# Altium schematic sheet OpAmpAndADC.SchDoc(OpAmpAndADC)
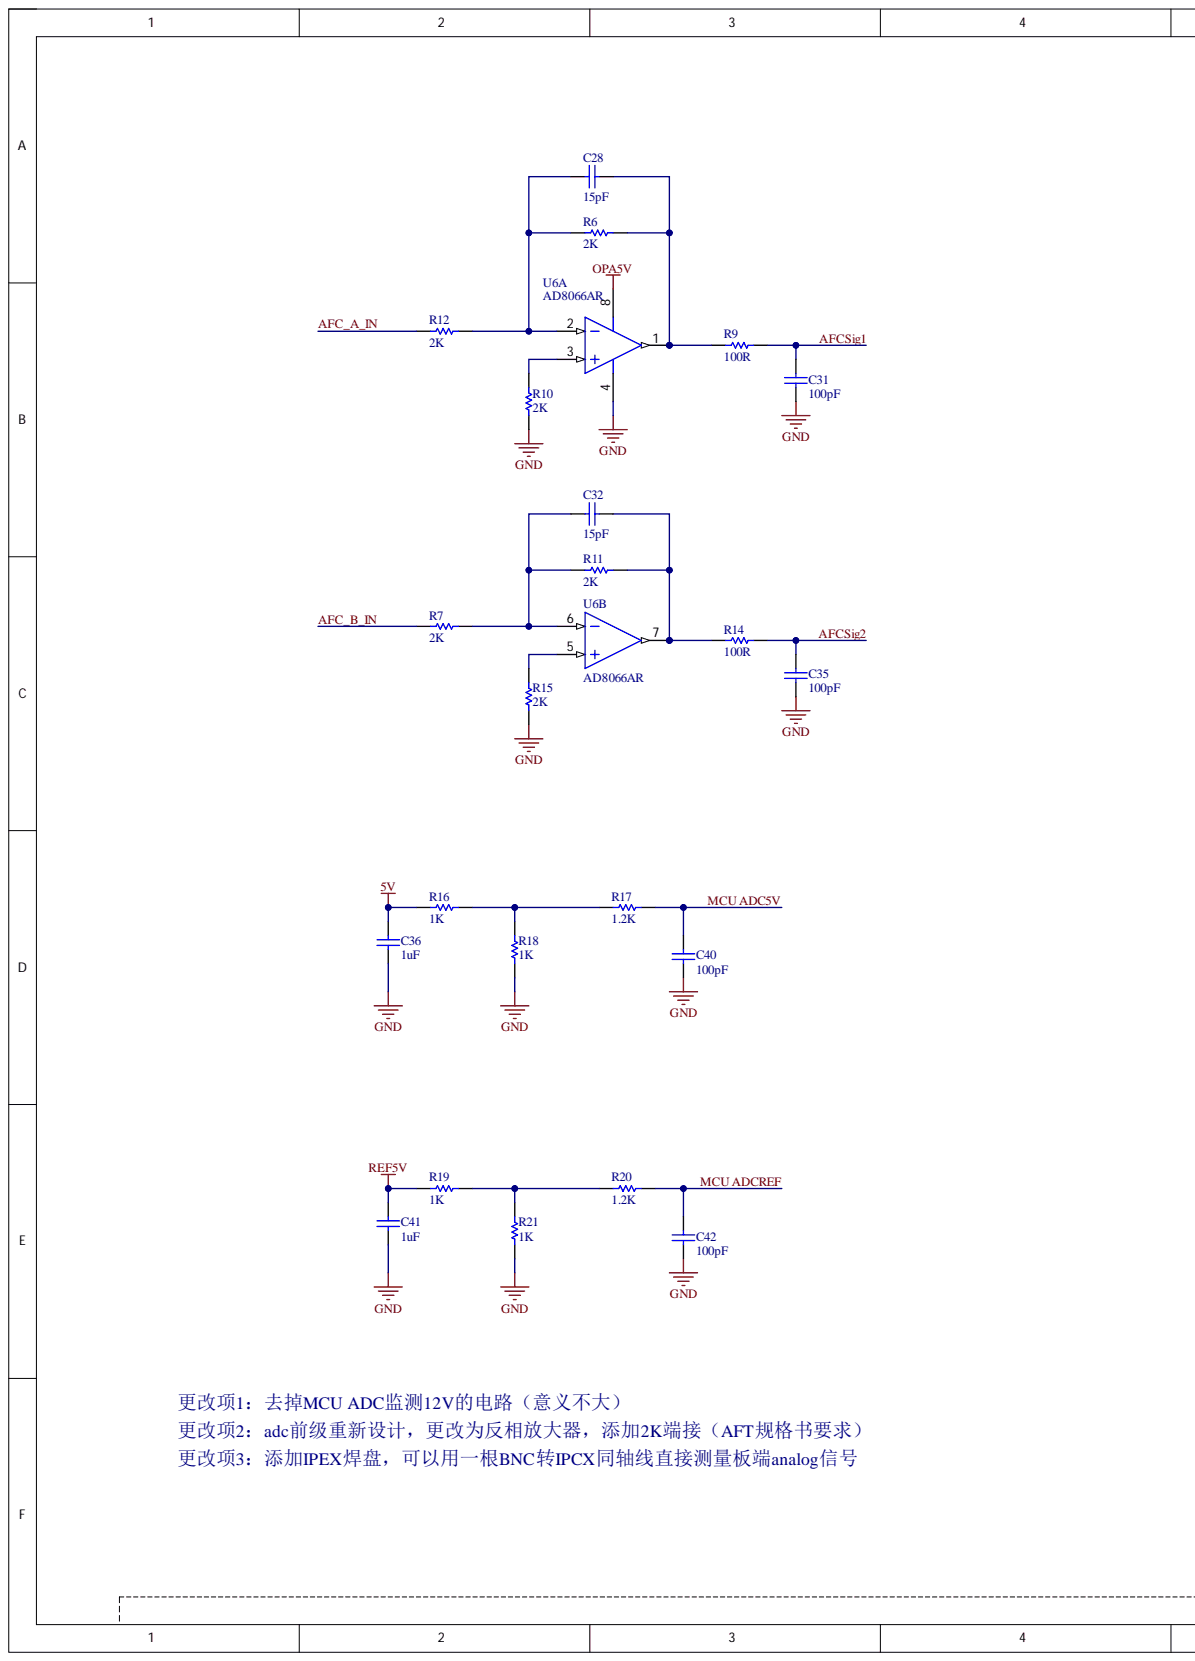
[diagram p0: OpAmpAndADC.SchDoc, left]
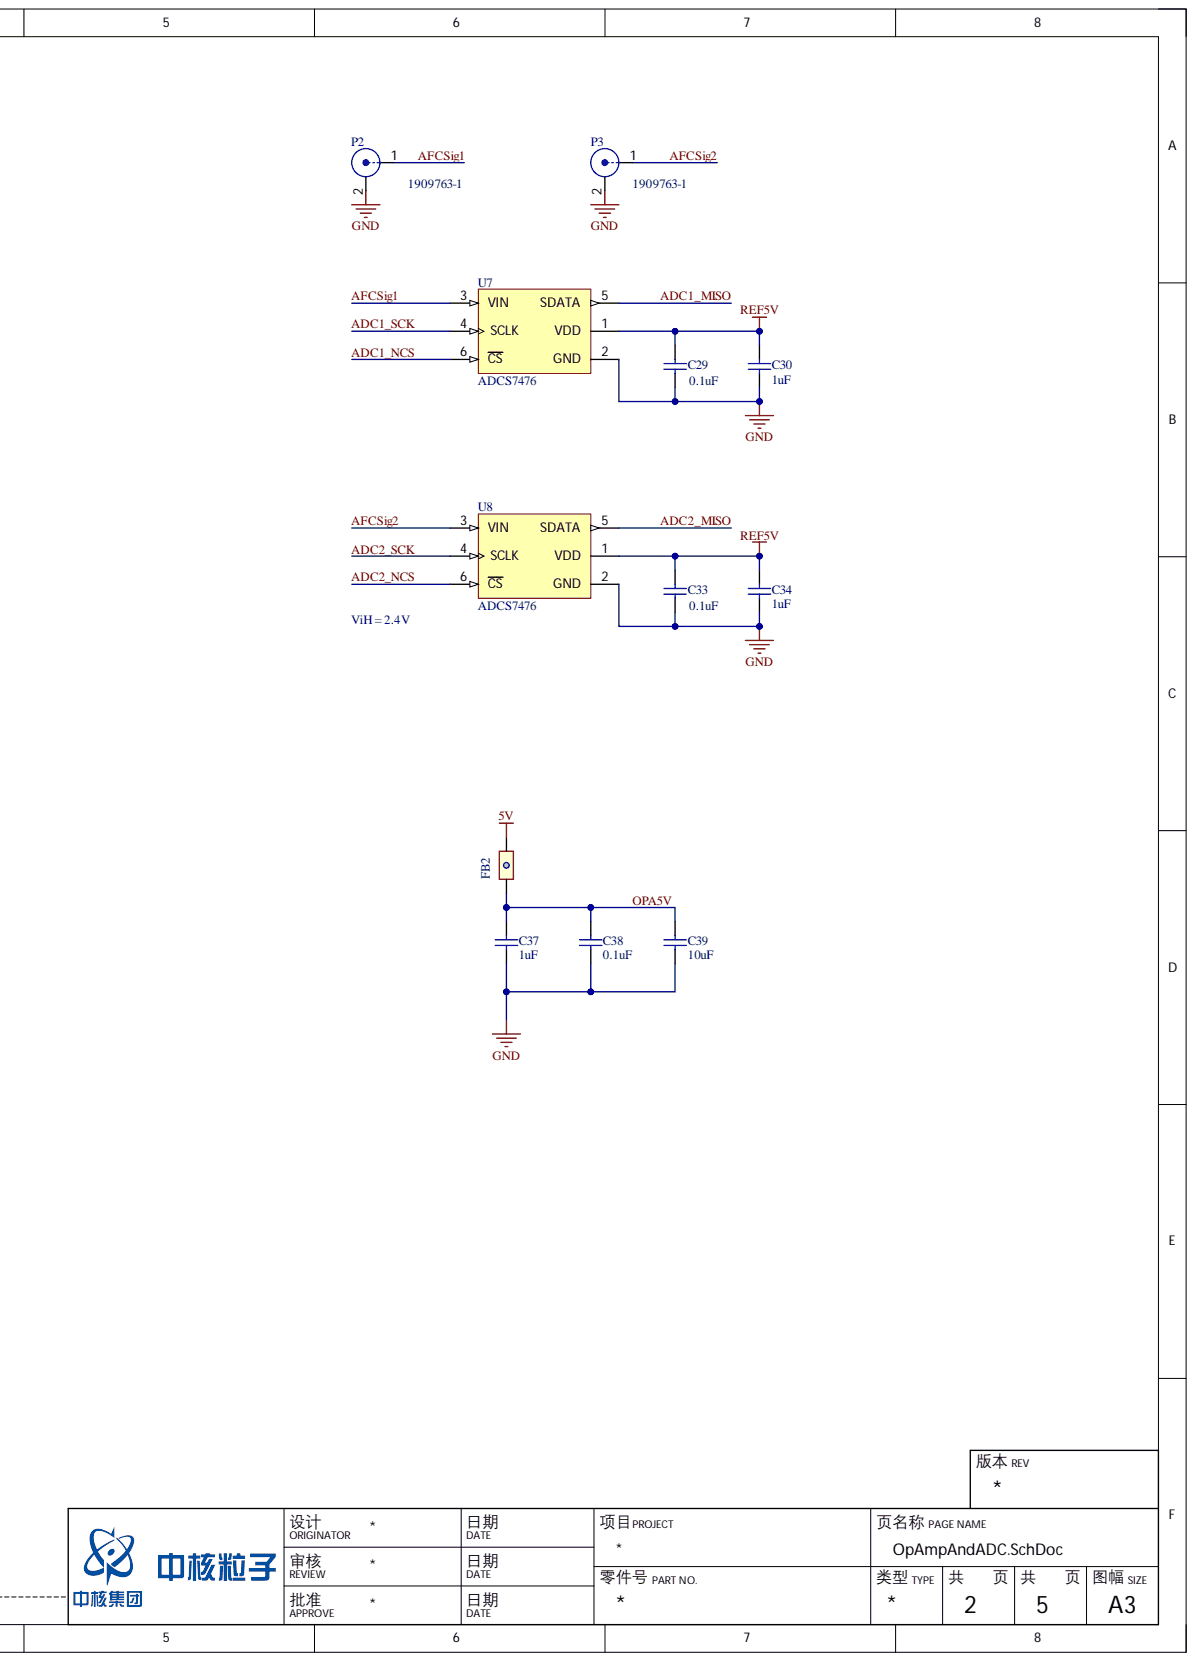
[diagram p1: OpAmpAndADC.SchDoc, right]

## Parts
C28  15pF  [C0402(1005)]
C29  0.1uF  [C0402(1005)]
C30  1uF  [C0402(1005)]
C31  100pF  [C0402(1005)]
C32  15pF  [C0402(1005)]
C33  0.1uF  [C0402(1005)]
C34  1uF  [C0402(1005)]
C35  100pF  [C0402(1005)]
C36  1uF  [C0402(1005)]
C37  1uF  [C0402(1005)]
C38  0.1uF  [C0402(1005)]
C39  10uF  [C0603(1608)]
C40  100pF  [C0402(1005)]
C41  1uF  [C0402(1005)]
C42  100pF  [C0402(1005)]
FB2  BLM18AG102SN1D  [FB0603(1608)]  (value not drawn)
P2  1909763-1  [1909763-1]
P3  1909763-1  [1909763-1]
R6  2K  [R0402(1005)]
R7  2K  [R0402(1005)]
R9  100R  [R0402(1005)]
R10  2K  [R0402(1005)]
R11  2K  [R0402(1005)]
R12  2K  [R0402(1005)]
R14  100R  [R0402(1005)]
R15  2K  [R0402(1005)]
R16  1K  [R0402(1005)]
R17  1.2K  [R0402(1005)]
R18  1K  [R0402(1005)]
R19  1K  [R0402(1005)]
R20  1.2K  [R0402(1005)]
R21  1K  [R0402(1005)]
U6  AD8066AR  [SOIC8_N]  (parts A,B)
U7  ADCS7476  [ADCS7476]
U8  ADCS7476  [ADCS7476]

## Nets
5V: C36.2 FB2.2 R16.1
GND: C29.1 C30.1 C31.1 C33.1 C34.1 C35.1 C36.1 C37.1 C38.1 C39.1 C40.1 C41.1 C42.1 P2.2 P3.2 R10.1 R15.1 R18.1 R21.1 U6.4 U7.2(GND) U8.2(GND)
REF5V: C29.2 C30.2 C33.2 C34.2 C41.2 R19.1 U7.1(VDD) U8.1(VDD)
ADC1_MISO: U7.5(SDATA)
ADC1_NCS: U7.6(CS)
ADC1_SCK: U7.4(SCLK)
ADC2_MISO: U8.5(SDATA)
ADC2_NCS: U8.6(CS)
ADC2_SCK: U8.4(SCLK)
AFC_A_IN: R12.1
AFC_B_IN: R7.1
AFCSig1: C31.2 P2.1 R9.2 U7.3(VIN)
AFCSig2: C35.2 P3.1 R14.2 U8.3(VIN)
MCU ADC5V: C40.2 R17.2
MCU ADCREF: C42.2 R20.2
OPA5V: C37.2 C38.2 C39.2 FB2.1 U6.8
NetC28_1: C28.1 R6.1 R12.2 U6.2
NetC28_2: C28.2 R6.2 R9.1 U6.1
NetC32_1: C32.1 R7.2 R11.1 U6.6
NetC32_2: C32.2 R11.2 R14.1 U6.7
NetR10_2: R10.2 U6.3
NetR15_2: R15.2 U6.5
NetR16_2: R16.2 R17.1 R18.2
NetR19_2: R19.2 R20.1 R21.2

## Library data of the parts
C28 C32 = Cap 15pF 0402: ceramic 25V; Component Kind: Standard; Library Name: RCL_temp.SchLib
C29 C33 C38 = Cap 0.1uF 0402-25V: ceramic 25V; 价格: ¥0.33@100; 制造商: KEMET; 型号: C0402C104M3RACTU; Component Kind: Standard; Library Name: RCL_release.SchLib; 供应商及库存编号: e络盟 2528342
C30 C34 C36 C37 C41 = Cap 1uF 0402-10V: ceramic 10V; 价格: ¥0.19@100; 制造商: KEMET; 型号: C0402C105K8PACTU; Component Kind: Standard; Library Name: RCL_release.SchLib; 供应商及库存编号: e络盟 1793827
C31 C35 C40 C42 = Cap 100pF 0402: ceremic 25V; Component Kind: Standard; Library Name: RCL_temp.SchLib
C39 = Cap 10uF 0603-25V: ceramic 25V; 价格: 5.86; 制造商: TDK; 型号: C1608JB1E106M080AC; Component Kind: Standard; Library Name: RCL_release.SchLib; 供应商及库存编号: Mouser 810-C1608JB1E106M08C
FB2 = FB-1K@100MHz 0603: FERRITE BEAD 1 KOHM 0603 1LN; 价格: ¥0.25@100; 制造商: MURATA; 名称: 磁珠; Component Kind: Standard; Library Name: RCL_release.SchLib; 供应商及库存编号: e络盟 1515671; 型号: BLM18AG102SN1D
P2 P3 = 1909763-1: IPEX PCB; Component Kind: Standard; Library Name: Connector_temp.SchLib
R6 R7 R10-R12 R15 = Res 2K 0402: Res ±1%; Component Kind: Standard; Library Name: RCL_release.SchLib
R9 R14 = Res 100R 0402: Res ±1%; Component Kind: Standard; Library Name: RCL_release.SchLib
R16 R18 R19 R21 = Res 1K 0402: Res ±1%; Component Kind: Standard; Library Name: RCL_release.SchLib
R17 R20 = Res 1.2K 0402: Res ±1%; Component Kind: Standard; Library Name: RCL_release.SchLib
U6 = AD8066AR: OPAMP VFB 145MHZ RRO 8SOIC; 价格: $3.318@1K; 制造商: Analog Devices Inc.; 型号: AD8066ARZ-R7; Component Kind: Standard; Library Name: IC_temp.SchLib; 供应商及库存编号: digikey AD8066ARZ-R7TR-ND
U7 U8 = ADCS7476: ADC 12BIT 1MSPS SOT-23-6; 价格: $1.56@1K; 制造商: Texas Instruments; 型号: ADCS7476AIMF/NOPB; Component Kind: Standard; Library Name: IC_temp.SchLib; 供应商及库存编号: digikey ADCS7476AIMF/NOPBTR-ND
